# Altium schematic sheet ATK-MCIMX335.SchDoc(ATK-MCIMX335)
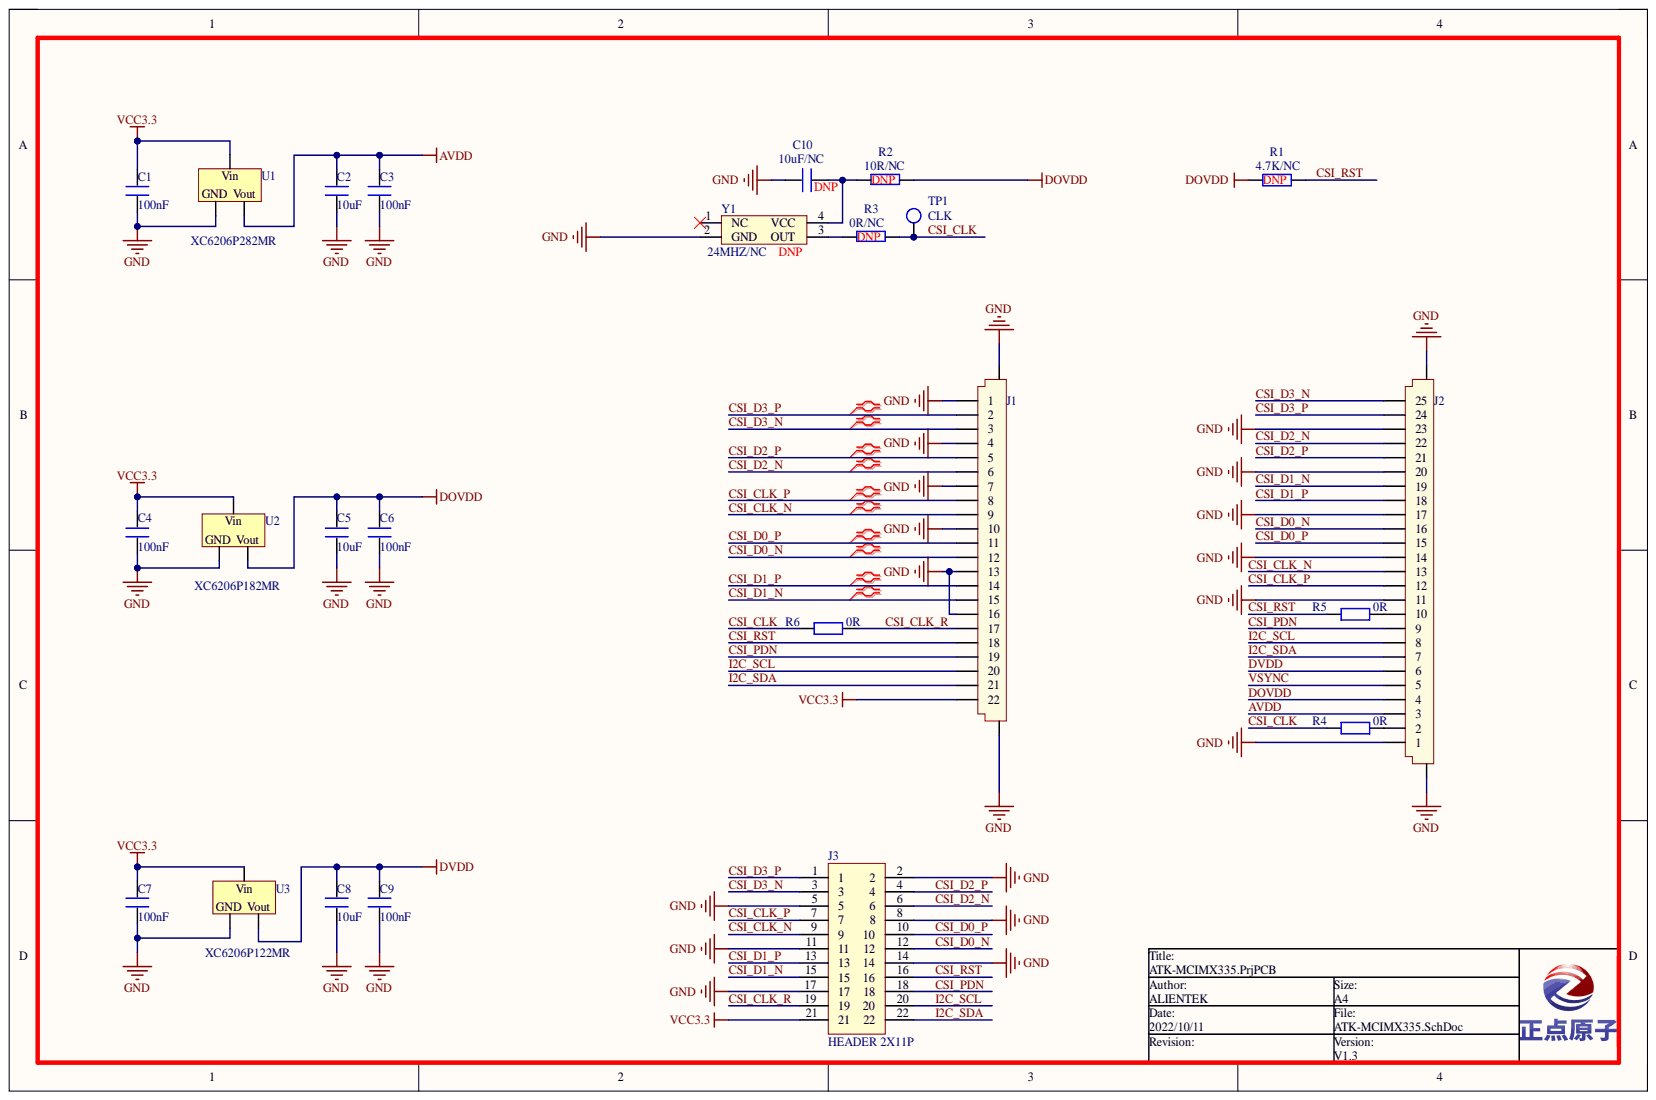
[diagram p0: ATK-MCIMX335.SchDoc]

## Parts
C1  100nF  [C 0603]
C2  10uF  [C 0603]
C3  100nF  [C 0603]
C4  100nF  [C 0603]
C5  10uF  [C 0603]
C6  100nF  [C 0603]
C7  100nF  [C 0603]
C8  10uF  [C 0603]
C9  100nF  [C 0603]
C10  10uF/NC  [C 0603]
J1  FPC1.0-22P  [FPC 0.5 22P H-S]  (value not drawn)
J2  FPC1.0-25P  [FPC 0.5 25P H-S]  (value not drawn)
J3  HEADER 2X11P  [HEADER 2.0 2X11P]
R1  4.7K/NC  [R 0603]
R2  10R/NC  [R 0603]
R3  0R/NC  [R 0603]
R4  0R  [R 0603]
R5  0R  [R 0603]
R6  0R  [R 0603]
TP1  CLK  [TP_0R70]
U1  XC6206P282MR  [SOT23-3L]
U2  XC6206P182MR  [SOT23-3L]
U3  XC6206P122MR  [SOT23-3L]
Y1  24MHZ/NC  [XTAL-3225 - S]

## Nets
GND: C1.1 C2.1 C3.1 C4.1 C5.1 C6.1 C7.1 C8.1 C9.1 C10.2 J1.0 J1.1 J1.4 J1.7 J1.10 J1.13 J1.16 J2.0 J2.1 J2.11 J2.14 J2.17 J2.20 J2.23 J3.2 J3.5 J3.8 J3.11 J3.14 J3.17 U1.1 U2.1 U3.1 Y1.2(GND)
VCC3.3: C1.2 C4.2 C7.2 J1.22 J3.21 U1.3 U2.3 U3.3
AVDD: C2.2 C3.2 J2.3 U1.2
CSI_CLK: R3.1 R4.2 R6.2 TP1.1
CSI_CLK_N: J1.9 J2.13 J3.9
CSI_CLK_P: J1.8 J2.12 J3.7
CSI_CLK_R: J1.17 J3.19 R6.1
CSI_D0_N: J1.12 J2.16 J3.12
CSI_D0_P: J1.11 J2.15 J3.10
CSI_D1_N: J1.15 J2.19 J3.15
CSI_D1_P: J1.14 J2.18 J3.13
CSI_D2_N: J1.6 J2.22 J3.6
CSI_D2_P: J1.5 J2.21 J3.4
CSI_D3_N: J1.3 J2.25 J3.3
CSI_D3_P: J1.2 J2.24 J3.1
CSI_PDN: J1.19 J2.9 J3.18
CSI_RST: J1.18 J3.16 R1.1 R5.2
DOVDD: C5.2 C6.2 J2.4 R1.2 R2.1 U2.2
DVDD: C8.2 C9.2 J2.6 U3.2
I2C_SCL: J1.20 J2.8 J3.20
I2C_SDA: J1.21 J2.7 J3.22
VSYNC: J2.5
NetC10_1: C10.1 R2.2 Y1.4(VCC)
NetJ2_2: J2.2 R4.1
NetJ2_10: J2.10 R5.1
NetR3_2: R3.2 Y1.3(OUT)

Not connected: Y1 1(NC)

## Library data of the parts
C1-C10 = C: Component Kind: Standard; Library Name: ALIENTEK_COMPONENT.IntLib
J1 = FPC-22P: FPC连接器; Parameter: 接口器件; 版权归属: 正点原子; BOM名称: FPC连接器; Component Kind: Standard; Library Name: 正点原子封装库V1.0.IntLib; 学习资源: www.openedv.com
J2 = FPC-25P: FPC连接器; Parameter: 接口器件; 版权归属: 正点原子; BOM名称: FPC连接器; Component Kind: Standard; Library Name: 正点原子封装库V1.0.IntLib; 学习资源: www.openedv.com
R1 R4-R6 = R: 贴片电阻; Parameter: 电阻; 版权归属: 正点原子; BOM名称: 贴片电阻; Component Kind: Standard; 学习资源: www.openedv.com; Library Name: Lycreturn.IntLib
R2 R3 = R: Component Kind: Standard; Library Name: ALIENTEK_COMPONENT.IntLib
TP1 = TP: Test Point 0.5mm; Priority: A; RK PN: TESTPOINT_0R5; Component Kind: Standard; Part Type: TP; Created by: JJJ
U1-U3 = XC6206: LDO 5.5V 200-300mA; Parameter: 芯片; 版权归属: 正点原子; Component Kind: Standard; Package: SOT-23; 学习资源: www.openedv.com; Library Name: Lycreturn.IntLib
Y1 = XTAL_S: 有源晶振; Component Kind: Standard; Library Name: ALIENTEK_COMPONENT.IntLib
J3 = HEADER 2X11P: Component Kind: Standard; Library Name: 新原理图库.SchLib
